# Altium schematic sheet SelfTestCircuit.SchDoc
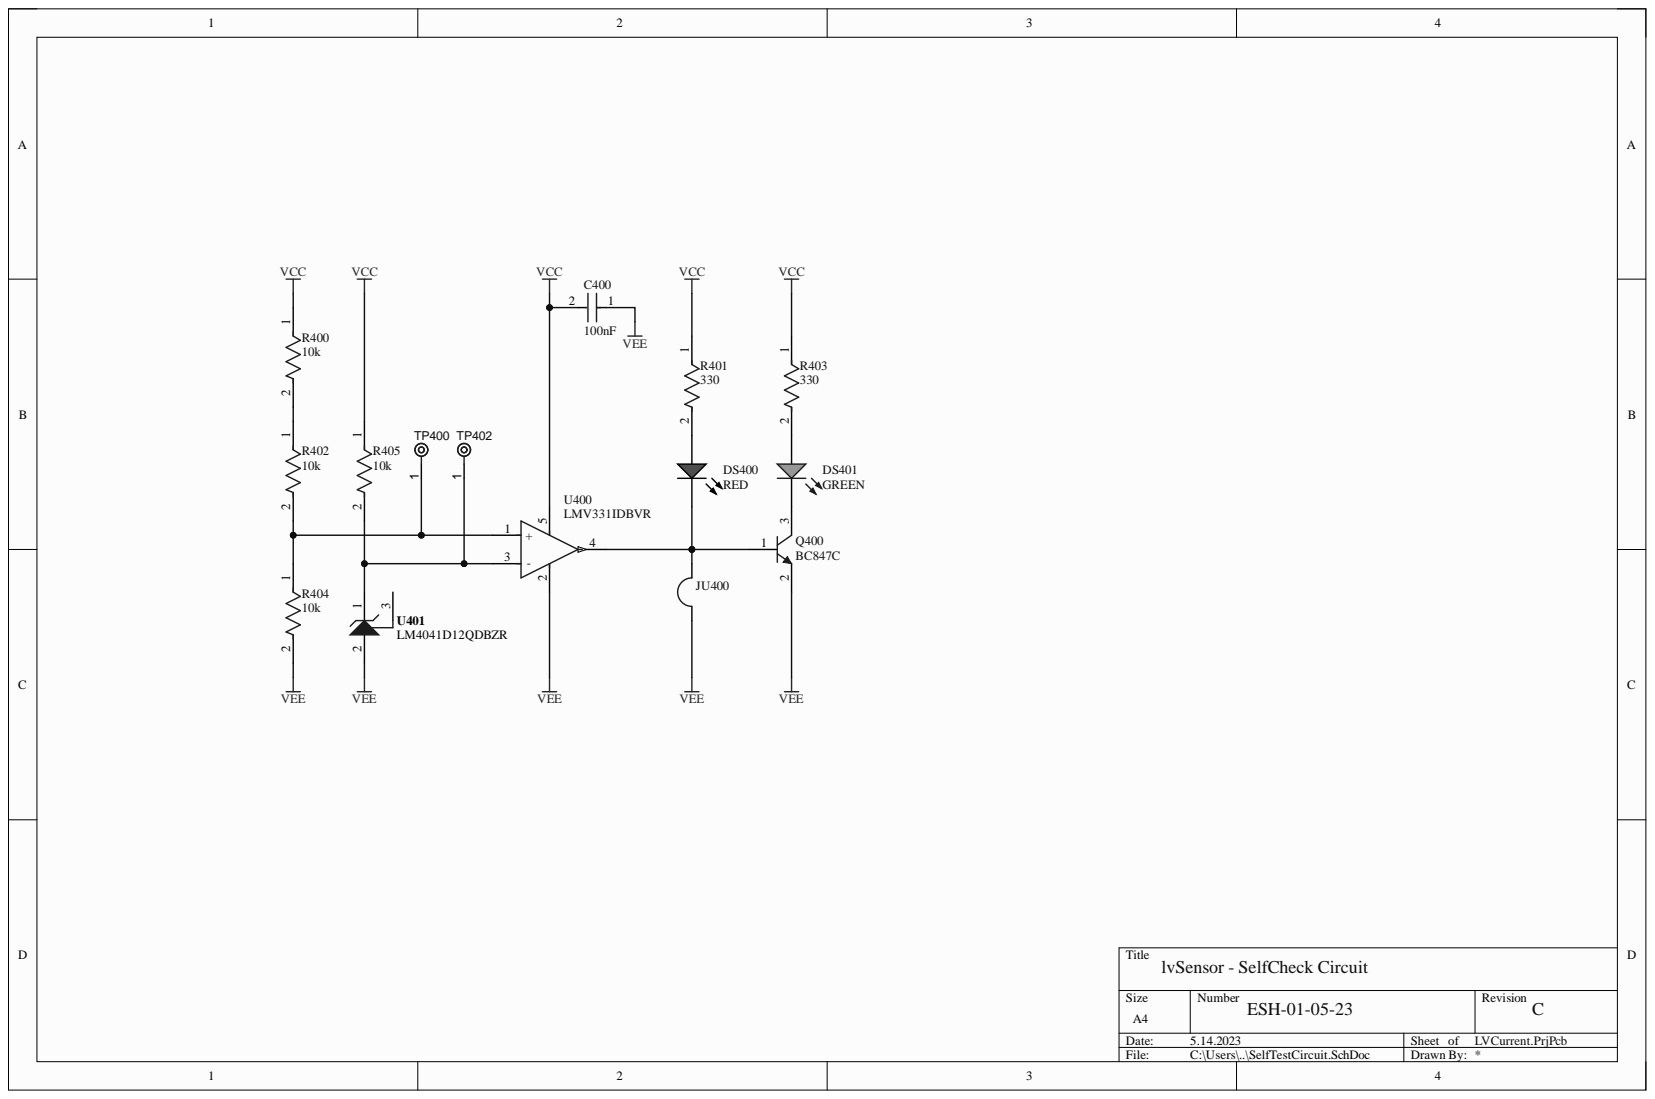
[diagram p0: SelfTestCircuit.SchDoc]

## Parts
C400  100nF  [FP-GRM21B-0_15-IPC_C]
DS400  RED  [LUMX-SML-LX1206IC_V]
DS401  GREEN  [DIAL-597-3311-407F_V]
JU400  Jumper_1206  [Jumper_1206]  (value not drawn)
Q400  BC847C  [INF-SOT23_L]
R400  10k  [FP-RC1206-MFG]
R401  330  [FP-RC1206-MFG]
R402  10k  [FP-RC1206-MFG]
R403  330  [FP-RC1206-MFG]
R404  10k  [FP-RC1206-MFG]
R405  10k  [FP-RC1206-MFG]
TP400  TPAD6R  [TP06R]  (value not drawn)  (no BOM)
TP402  TPAD6R  [TP06R]  (value not drawn)  (no BOM)
U400  LMV331IDBVR  [FP-DBV0005A-MFG]
U401  LM4041D12QDBZR  [DBZ0003A_N]

## Nets
VCC: C400.2 R400.1 R401.1 R403.1 R405.1 U400.5
VEE: C400.1 JU400.2 Q400.2 R404.2 U400.2 U401.2
NetDS400_1: DS400.1 R401.2
NetDS400_2: DS400.2 JU400.1 Q400.1 U400.4
NetDS401_1: DS401.1 R403.2
NetDS401_2: DS401.2 Q400.3
NetR400_2: R400.2 R402.1
NetR402_2: R402.2 R404.1 TP400.1 U400.1(+)
NetR405_2: R405.2 TP402.1 U400.3(-) U401.1

Not connected: U401 3

## Library data of the parts
C400 = CMP-2007-03541-2: CC0805KPX7R9104  0805 Capacitor; Capacitance: 10uF; Dielectric: X5R; Material: Ceramic; Tolerance: 10%; Voltage: 25V; Case Code (Imperial): 0805; Case Code (Metric): 2012; Case/Package: 0805; Component Kind: Standard; Depth: 1.25mm; Height: 1.25mm; Lead Free: Lead Free; Length: 2mm; Library Name: Altium Content Vault; Max Operating Temperature: 85°C; Min Operating Temperature: -55°C; Mount: Surface Mount; Package Quantity: 1; Packaging: Tape and Reel; Radiation Hardening: No; Termination: SMD/SMT; Thickness: 0.053inch; Voltage Rating: 25V; Voltage Rating (DC): 25V; Width: 0.049inch
DS400 = CMP-2000-07123-1: LED Red, 65 mW, -40 to 85 degC, 2-Pin SMD, RoHS, Tape and Reel; Manufacturer: Lumex; Part No: SML-LX1206IC-TR; Intensity: 6mcd; LED Material: GaAsP; Lens Appearance: ColorlessNonDiffused; Number of LEDs: 1; Peak Wavelength: 635nm; Pin_Count: 2; Reverse Voltage: 5(Min)V; Type: Uni-Color; Viewing Angle: 160; Color: Red; Component Kind: Standard; Datasheet URL: http://www.lumex.com/content/files/ProductAttachment/SML-LX1206IC-TR.pdf; Datasheet Version: Rev. J, 08/2012; Dimension: 3.2x1.6x1.1mm; Dominant Wavelength: N/A; ECCN: EAR99; Family: SML-LX1206IC; HTS: 8541402000; Lens Dimensions: 2x1.6x0.5mm; Library Name: Altium Content Vault; Manufacturer URL: http://www.lumexinstruments.com/; Mounting: SurfaceMount; Mounting Technology: SurfaceMount; Operating Temperature: -25to85C; Package Description: 2-Pin Surface Mount Device, Body 3.2 x 1.5 mm; Package Reference: SML-LX1206IC; Packaging: TapeandReel; RoHS: Unknown; Supplier: Lumex; Supplier Standard Pack: 3,000; Supplier_Package: SMD
DS401 = CMP-2000-05651-1: LED Green, 75 mW, 30 mA, -30 to 85 degC, 2-Pin SMD, RoHS, Tape and Reel; Manufacturer: Dialight; Chip Material: GaP; DELETED: Compliant; Emitting Color: YellowGreen; Forward Current: 30mA; Forward Voltage: 2.5V; High Brightness: No; Lens Type: NonDiffused; Lum Intensity: 7.5mcd; Main Category: ChipLED; Number of  Elements: 1; Peak Wavelength: 560nm; Power Dissipation: 75W; Product Depth (mm): 1.5mm; Product Length (mm): 3mm; Reverse Voltage: 4V; Shape Type: Rectangular; Test Current (It): 20mA; Type: Uni-Color; Viewing Angle: 70deg; Component Kind: Standard; Datasheet URL: https://datasheet.ciiva.com/15117/getdatasheetpartid-142484-15117764.pdf; Datasheet Version: 07/2002; Lens Dimensions: 2x1.5x0.9mm; Library Name: Altium Content Vault; Luminous Intensity: 7.5mcd; Manufacturer URL: http://www.dialight.com/; Mounting: SurfaceMount; Mounting Technology: SurfaceMount; Operating Temp Range: -30Cto85C; Operating Temperature Classification: Commercial; Package Description: 2-Pin Surface Mount Device, Body 1.5 x 3 mm; Package Reference: 597-3311-407F; Package Type: SMD; Packaging: TapeandReel; Pin Count: 2; Product Height (mm): 1.5mm; Rad Hardened: No
Q400 = CMP-1177-00037-1: NPN Silicon AF Transistor, 45 V VCEO, 100 mA IC, SOT23, Reel, Green; Manufacturer: Infineon; fT (typ): 250Â MHz; Green: TRUE; IBM (max): 200Â mA; IC (max): 100Â mA; ICBO (max): 15Â nA; Ptot (max): 330Â mW; VCBO (max): 50Â V; VCEO (max): 45Â V; VEBO (max): 6Â V; Component Kind: Standard; ComponentLink1Description: Manufacturer URL; ComponentLink1URL: http://www.infineon.com/; ComponentLink2Description: Datasheet; ComponentLink2URL: http://www.infineon.com/dgdl/bc847series_bc848series_bc849series_bc850series.pdf?folderId=db3a304314dca389011541d30fa21656&fileId=db3a304314dca389011541d4630a1657; ComponentLink3Description: Package Specification; ComponentLink3URL: http://www.infineon.com/dgdl/SOT23-Package_Overview.pdf?folderId=db3a30431936bc4b0119532290053c66&fileId=db3a30431936bc4b0119533468123c85; ICM (max): 200Â mA; Library Name: Altium Content Vault; Mounting Technology: Surface Mount; PackageDescription: 3-Pin Small Outline Transistor Package, 1.3 x 2.9 mm Body, 0.95 mm Pitch; PackageReference: SOT23; PackageVersion: Apr-08; Packing: Reel; PartNumber: BC847C; Polarity: NPN
R400-R405 = CMP-2100-03681-2: WR12X3300FTL  1206 Resistors; Manufacturer: YAGEO; Manufacturer Part Number: RC1206JR-070RL; Power Rating: 0.25W; Resistance: 0Ω; Temperature Coefficient: 300ppm/°C; Tolerance: 5%; Value: 0Ω; Voltage Rating: 200V; Automotive: No; Category: Res; Component Kind: Standard; ComponentLink2Description: Datasheet; ComponentLink2URL: https://www.yageo.com/upload/media/product/productsearch/datasheet/rchip/PYu-RC_Group_51_RoHS_L_10.pdf; Composition: Thick Film; Device Class L1: Passive Components; Device Class L2: Resistors; Device Class L3: Chip SMD Resistors; Digikey Description: RES SMD 0 OHM JUMPER 1/4W 1206; Footprint Url: https://www.yageo.com/upload/website/yageo_PYu-R_Mount_10_19050818_343.pdf; Height: 0.65mm; Ipc Land Pattern Name: RESC310160X55; Lead Free: Yes; Library Name: Altium Content Vault; Mouser Description: Resistor: thick film; SMD; 1206; 0O; 0.25W; ±5%; -55÷155°C; Octopart: https://octopart.com/rc1206jr-070rl-yageo-40025601; Package: RC1206; RoHS: TRUE; Series: RC; Temperature: -55°C to +155°C; Temperature Range High: +155°C; Temperature Range Low: -55°C
TP400 TP402 = CMP-00028-00002-2: 0.6mm round; Library Name: LabDevices; Pins: 1
U400 = CMP-04896-000030-1: IC GP LV COMPARATOR SOT-23-5; Manufacturer: Texas Instruments; Input Bias Current: 0.015uA; Input Offset Voltage: 1.7mV; Max Junction Temp: +150°C; Max Supply Voltage: 5.5V; Min Supply Voltage: 2.7V; Nominal Supply Current: 40-60uA; Number Of Channels: 1; Output Current Per Channel: 23-84mA; Standoff Height: 0mm; Voltage Gain: 50V/mV; Ambient Temperature Range High: +125°C; Ambient Temperature Range Low: -40°C; Automotive: No; Category: IC; Component Kind: Standard; ComponentLink2Description: Datasheet; ComponentLink2URL: http://www.ti.com/lit/ds/symlink/lmv331.pdf; Device Class L1: Integrated Circuits (ICs); Device Class L2: Linear ICs; Device Class L3: Comparators; Digikey Description: IC GP LV COMPARATOR SOT-23-5; Height: 1.45mm; Ipc Land Pattern Name: SOT95P280X145-5; Lead Free: Yes; Library Name: Altium Content Vault; Manufacturer Part Number: LMV331IDBVR; Mouser Description: Analog Comparators Single GP LV; Octopart: https://octopart.com/lmv331idbvr-texas+instruments-428444; Package: DBV0005A; RoHS: TRUE; Temperature: -40°C to +125°C; Temperature Range High: +125°C; Temperature Range Low: -40°C
U401 = CMP-0366-00795-2: Precision Micropower Shunt Voltage Reference, 1.0% accuracy, 1.225 V, 15 ppm / degC, 12 mA, -40 to 125 degC, 3-pin SOT-23 (DBZ), Green (RoHS & no Sb/Br); Manufacturer: Texas Instruments; Initial Accuracy @ 25/deg C(%): 1; Iout/Iz(Max)(mA): 12; Min Iz for Regulation(uA): 60; Rating: Catalog; Temp Coeff(Max)(ppm/ degree C): 150; Temp Coeff(Typ)(ppm/ degree C): 15; VO(V): 1.225; Code_JEDEC: TO-236; Component Kind: Standard; ComponentLink1Description: Manufacturer URL; ComponentLink1URL: http://www.ti.com/; ComponentLink2Description: Datasheet; ComponentLink2URL: http://www.ti.com/general/docs/lit/getliterature.tsp?genericPartNumber=LM4041D12&fileType=pdf; ComponentLink3Description: Package Specification; ComponentLink3URL: http://www.ti.com/litv/pdf/mpds108a; DatasheetVersion: SLCS146E; Eco-Plan: Green (RoHS & no Sb/Br); Library Name: Altium Content Vault; Mounting Technology: Surface Mount; PackageDescription: 3-Pin Plastic Small Outline, Body 2.92 x 1.3 mm, Pitch 0.95 mm; PackageReference: DBZ0003A; PackageVersion: 4203227/B; PartNumber: LM4041D12QDBZR; SubFamily: Shunt Voltage Reference
JU400 = Jumper_1206: Component Kind: Standard; Library Name: Jumpers.SchLib
